# Altium schematic sheet Dataflow.SchDoc
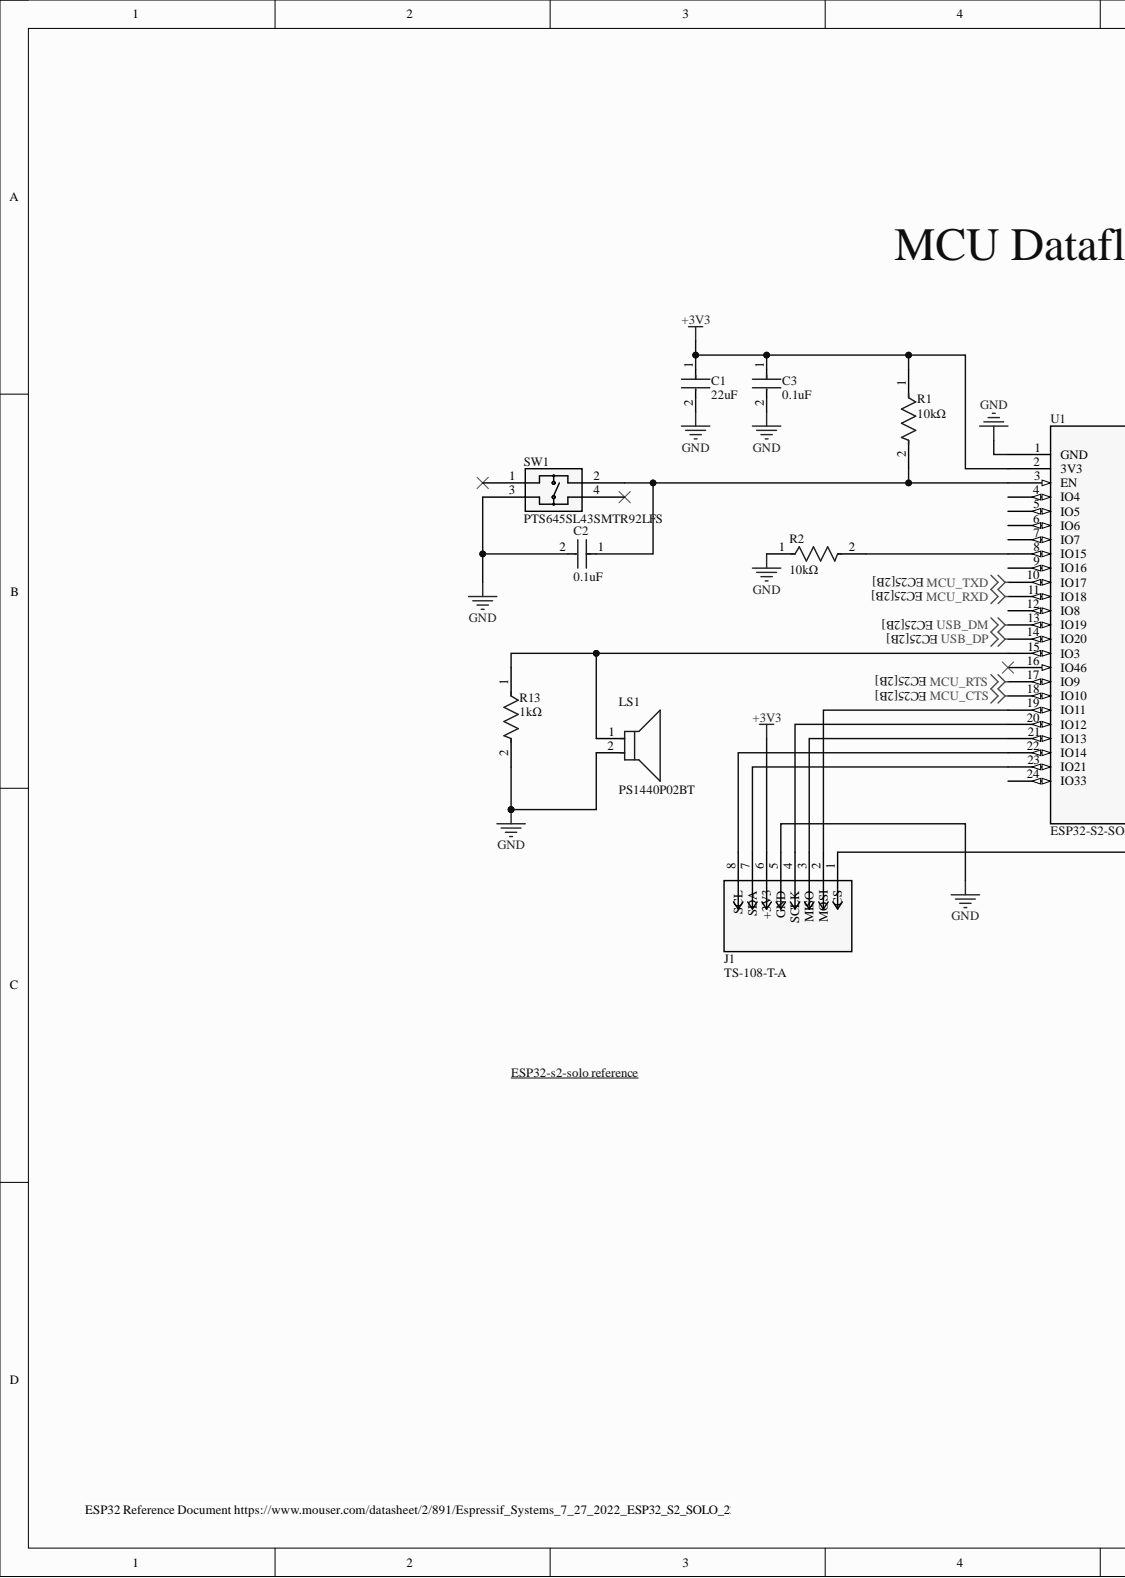
[diagram p0: Dataflow.SchDoc, left]
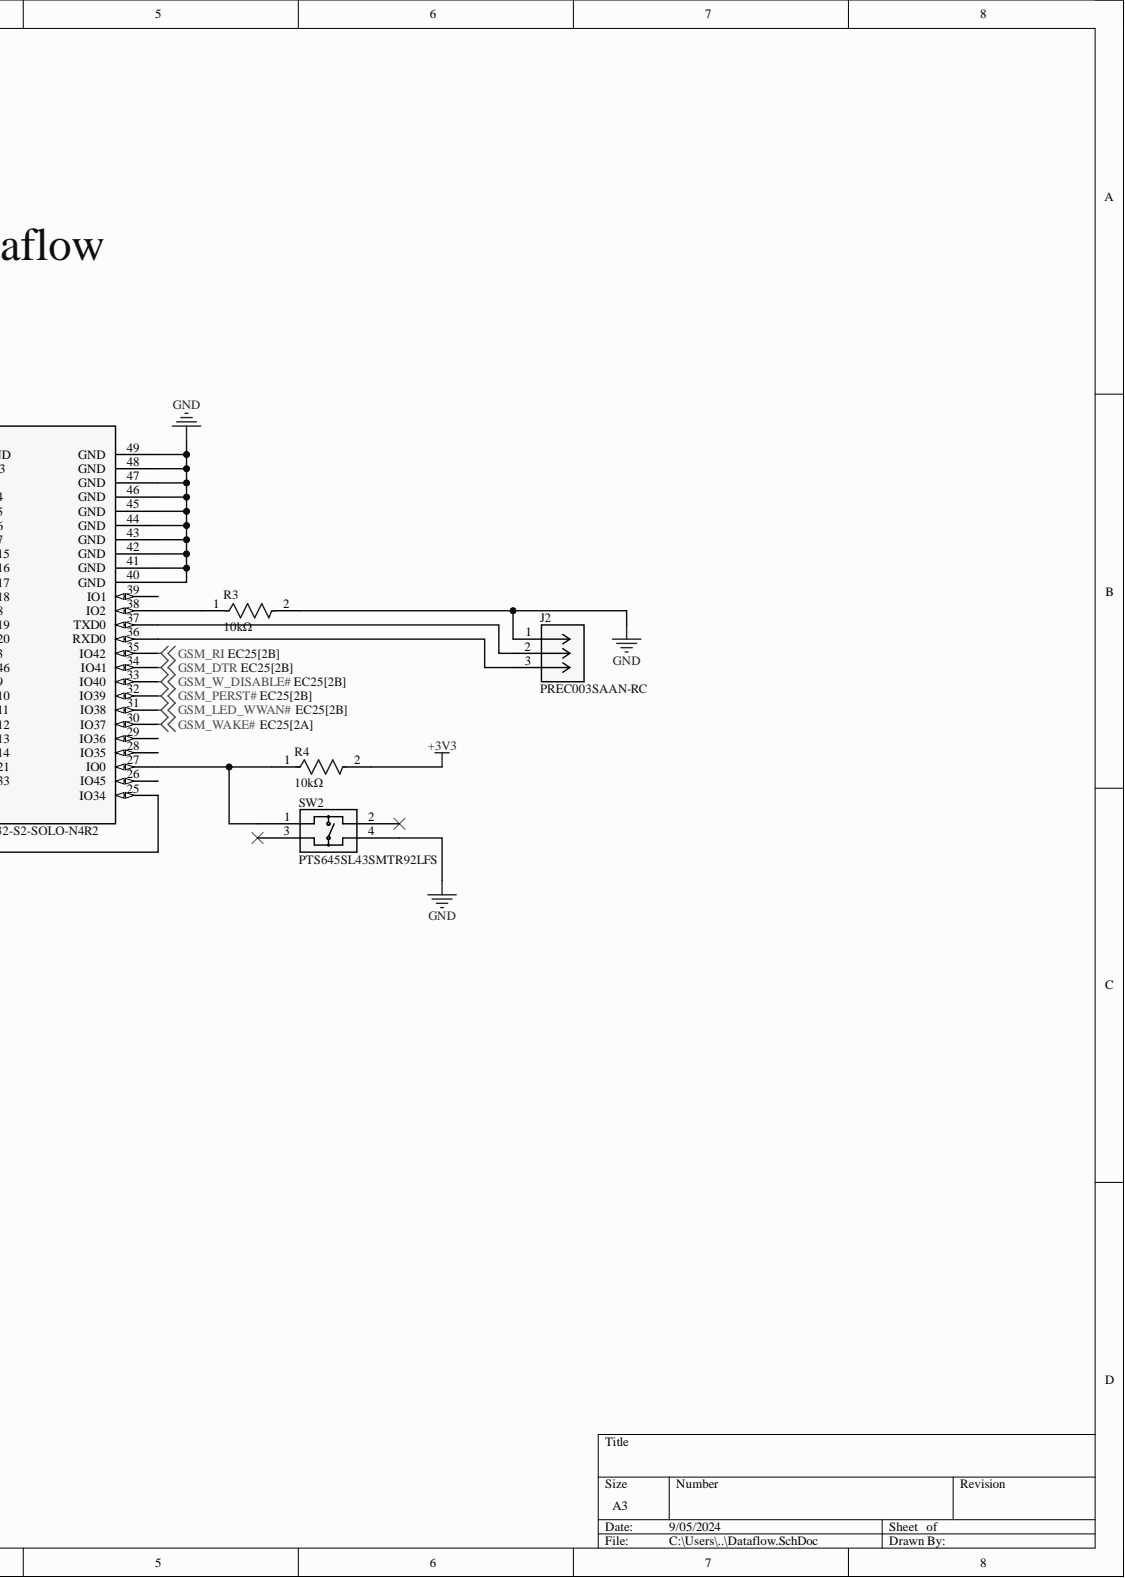
[diagram p1: Dataflow.SchDoc, right]

## Parts
C1  22uF  [FP-C3225-250-0_4-IPC_B]
C2  0.1uF  [FP-C0805C104M5UACTU-MFG]
C3  0.1uF  [FP-C0805C104M5UACTU-MFG]
J1  TS-108-T-A  [CON8_1X8_TU_HTS_SAI]
J2  PREC003SAAN-RC  [CONN_PREC003SAAN-RC_SUL]
LS1  PS1440P02BT  [FP-PS1440P02BT-MFG]
R1  10kΩ  [FP-RMCF0603-IPC_C]
R2  10kΩ  [FP-RMCF0603-IPC_C]
R3  10kΩ  [FP-RMCF0603-IPC_C]
R4  10kΩ  [FP-RMCF0603-IPC_C]
R13  1kΩ  [FP-RMCF0603-IPC_C]
SW1  PTS645SL43SMTR92LFS  [PTS645SL43SMTR92LFS_CNK]
SW2  PTS645SL43SMTR92LFS  [PTS645SL43SMTR92LFS_CNK]
U1  ESP32-S2-SOLO-N4R2  [MOD41_ESP32-S2-SOLO_EXP]

## Nets
+3V3: C1.1 C3.1 J1.6(+3V3) R1.1 R4.2 U1.2(3V3)
GND: C1.2 C2.2 C3.2 J1.5(GND) J2.1 LS1.2 R2.1 R3.2 R13.2 SW1.3 SW2.4 U1.1(GND) U1.40(GND) U1.41(GND) U1.42(GND) U1.43(GND) U1.44(GND) U1.45(GND) U1.46(GND) U1.47(GND) U1.48(GND) U1.49(GND)
GSM_DTR: U1.34(IO41)
GSM_LED_WWAN#: U1.31(IO38)
GSM_PERST#: U1.32(IO39)
GSM_RI: U1.35(IO42)
GSM_W_DISABLE#: U1.33(IO40)
GSM_WAKE#: U1.30(IO37)
MCU_CTS: U1.18(IO10)
MCU_RTS: U1.17(IO9)
MCU_RXD: U1.11(IO18)
MCU_TXD: U1.10(IO17)
USB_DM: U1.13(IO19)
USB_DP: U1.14(IO20)
NetC2_1: C2.1 R1.2 SW1.2 U1.3(EN)
NetJ1_1: J1.1(CS) U1.25(IO34)
NetJ1_2: J1.2(MOSI) U1.19(IO11)
NetJ1_3: J1.3(MISO) U1.21(IO13)
NetJ1_4: J1.4(SCLK) U1.20(IO12)
NetJ1_7: J1.7(SDA) U1.23(IO21)
NetJ1_8: J1.8(SCL) U1.22(IO14)
NetJ2_2: J2.2 U1.37(TXD0)
NetJ2_3: J2.3 U1.36(RXD0)
NetLS1_1: LS1.1 R13.1 U1.15(IO3)
NetR2_2: R2.2 U1.8(IO15)
NetR3_1: R3.1 U1.38(IO2)
NetR4_1: R4.1 SW2.1 U1.27(IO0)

Not connected: SW1 1 4; SW2 2 3; U1 4(IO4) 5(IO5) 6(IO6) 7(IO7) 9(IO16) 12(IO8) 16(IO46) 24(IO33) 26(IO45) 28(IO35) 29(IO36) 39(IO1)

## Library data of the parts
C1 = CMP-2000-06052-2: C3225X7R1C226M250AC Multilayer Ceramic Capacitors 22uF ±20% 16V X7R SMD 1210; Capacitance: 22uF; Case Code (Imperial): 1210; Case Code (Metric): 3225; Case/Package: 1210; Component Kind: Standard; Construction: Flat; Depth: 2.5mm; Dielectric: X7R; Dielectric Material: Ceramic; Height: 2.5mm; Lead Free: Lead Free; Length: 3.2mm; Library Name: Altium Content Vault; Max Operating Temperature: 125°C; Min Operating Temperature: -55°C; Mount: Surface Mount; Number of Pins: 2; Package Quantity: 1; Packaging: Tape and Reel; Radiation Hardening: No; REACH SVHC: No SVHC; Resistor Type: Ceramic; RoHS Compliant: Yes; Series: C; Termination: SMD/SMT; Thickness: 0.11inch; Tolerance: 20%; Voltage Rating: 16V; Voltage Rating (DC): 16V; Weight: 0.000564oz; Width: 0.098inch
C2 C3 = CMP-2007-03742-2: C0805C104M5UACTU CAP CER 0.1UF 50V Z5U 0805; Capacitance: 100nF; Dielectric Material: Ceramic; Dissipation Factor: 4%; Tolerance: 20%; Case Code (Imperial): 0805; Case Code (Metric): 2012; Case/Package: 0805; Component Kind: Standard; Construction: Flat; Contact Plating: Tin; Depth: 1.25mm; Dielectric: Z5U; Height: 0.78mm; Lead Free: Lead Free; Lead Pitch: 0.75mm; Length: 2mm; Library Name: Altium Content Vault; Max Operating Temperature: 85°C; Min Operating Temperature: 10°C; Mount: Surface Mount; Number of Pins: 2; Package Quantity: 1; Packaging: Tape and Reel; Radiation Hardening: No; REACH SVHC: No SVHC; RoHS Compliant: Yes; Series: C; Termination: SMD/SMT; Thickness: 0.035inch; Voltage: 50V; Voltage Rating: 50V; Voltage Rating (DC): 50V; Width: 1.2mm
J1 = TS-108-T-A: No Description Available; Mfr_Name: Samtec Inc; Component Kind: Standard; Library Name: TS-108-T-A.SchLib; RefDes: RefDes; Type: Type
J2 = PREC003SAAN-RC: No Description Available; Mfr_Name: Sullins; Component Kind: Standard; Digi-Key_Part_Number_1: S1012EC-03-ND; Library Name: PREC003SAAN-RC.SchLib; RefDes: RefDes; Type: Type
LS1 = CMP-08413-000003-1: AUDIO PIEZO TRANSDUCER 30V TH; Frequency: 4kHz; Number of Terminals/Pins: 2; Operating Supply Voltage: 3V; Washable: No; Component Kind: Standard; Diameter: 14mm; Height: 8mm; Lead Free: Lead Free; Library Name: Altium Content Vault; Max Operating Temperature: 70°C; Min Operating Temperature: -10°C; Mount: Through Hole; Package Quantity: 350; Packaging: Tape and Reel; RoHS Compliant: Yes; Self Resonant Frequency: 4kHz; Termination: PC Pins; Voltage Rating (DC): 3V
R1-R4 = CMP-26527-002098-1: RMCF0603JT10K0 10kΩ ±5% 0.1W 0603 Thick Film Chip Resistor AEC-Q200 compliant; Max Power Dissipation: 100mW; Resistance: 10kR; Temperature Coefficient: 100ppm/°C; Case Code (Imperial): 0603; Case Code (Metric): 1608; Case/Package: 0603; Component Kind: Standard; Construction: Rectangular; Contact Plating: Tin; Depth: 0.8mm; Features: Automotive AEC-Q200; Height: 0.022inch; Lead Free: Lead Free; Length: 1.55mm; Library Name: Altium Content Vault; Max Operating Temperature: 155°C; Military Standard: Not; Min Operating Temperature: -55°C; Mount: Surface Mount; Number of Terminations: 2; Packaging: Tape and Reel; Power Rating: 100mW; Radiation Hardening: No; Resistor Type: Thick Film; Series: RMCF; Size Code: 0603; Tolerance: 5%; Width: 0.031inch
R13 = CMP-26527-001474-1: RMCF0603JT1K00 1kΩ ±5% 0.1W 0603 Thick Film Chip Resistor AEC-Q200 compliant; Max Power Dissipation: 100mW; Resistance: 1kR; Temperature Coefficient: 100ppm/°C; Working Voltage: 75V; Case Code (Imperial): 0603; Case Code (Metric): 1608; Case/Package: 0603; Component Kind: Standard; Construction: Rectangular; Contact Plating: Tin; Depth: 0.8mm; Features: Automotive AEC-Q200; Height: 0.022inch; Lead Free: Lead Free; Length: 1.55mm; Library Name: Altium Content Vault; Max Operating Temperature: 155°C; Military Standard: Not; Min Operating Temperature: -55°C; Mount: Surface Mount; Number of Terminations: 2; Packaging: Tape and Reel; Power Rating: 100mW; Radiation Hardening: No; Resistor Type: Thick Film; RoHS Compliant: Yes; Series: RMCF; Technology: THICK FILM; Tolerance: 5%; Width: 0.031inch
SW1 SW2 = PTS645SL43SMTR92LFS: No Description Available; Mfr_Name: C&K Components; Component Kind: Standard; Library Name: PTS645SL43SMTR92LFS.SchLib; RefDes: RefDes; Type: Type
U1 = ESP32-S2-SOLO-N4R2: No Description Available; Mfr_Name: Espressif Systems; Component Kind: Standard; Library Name: ESP32-S2-SOLO-N4R2.SchLib; RefDes: RefDes; Type: RF
